# Altium schematic sheet Schamatic1_.SchDoc(Schamatic1_)
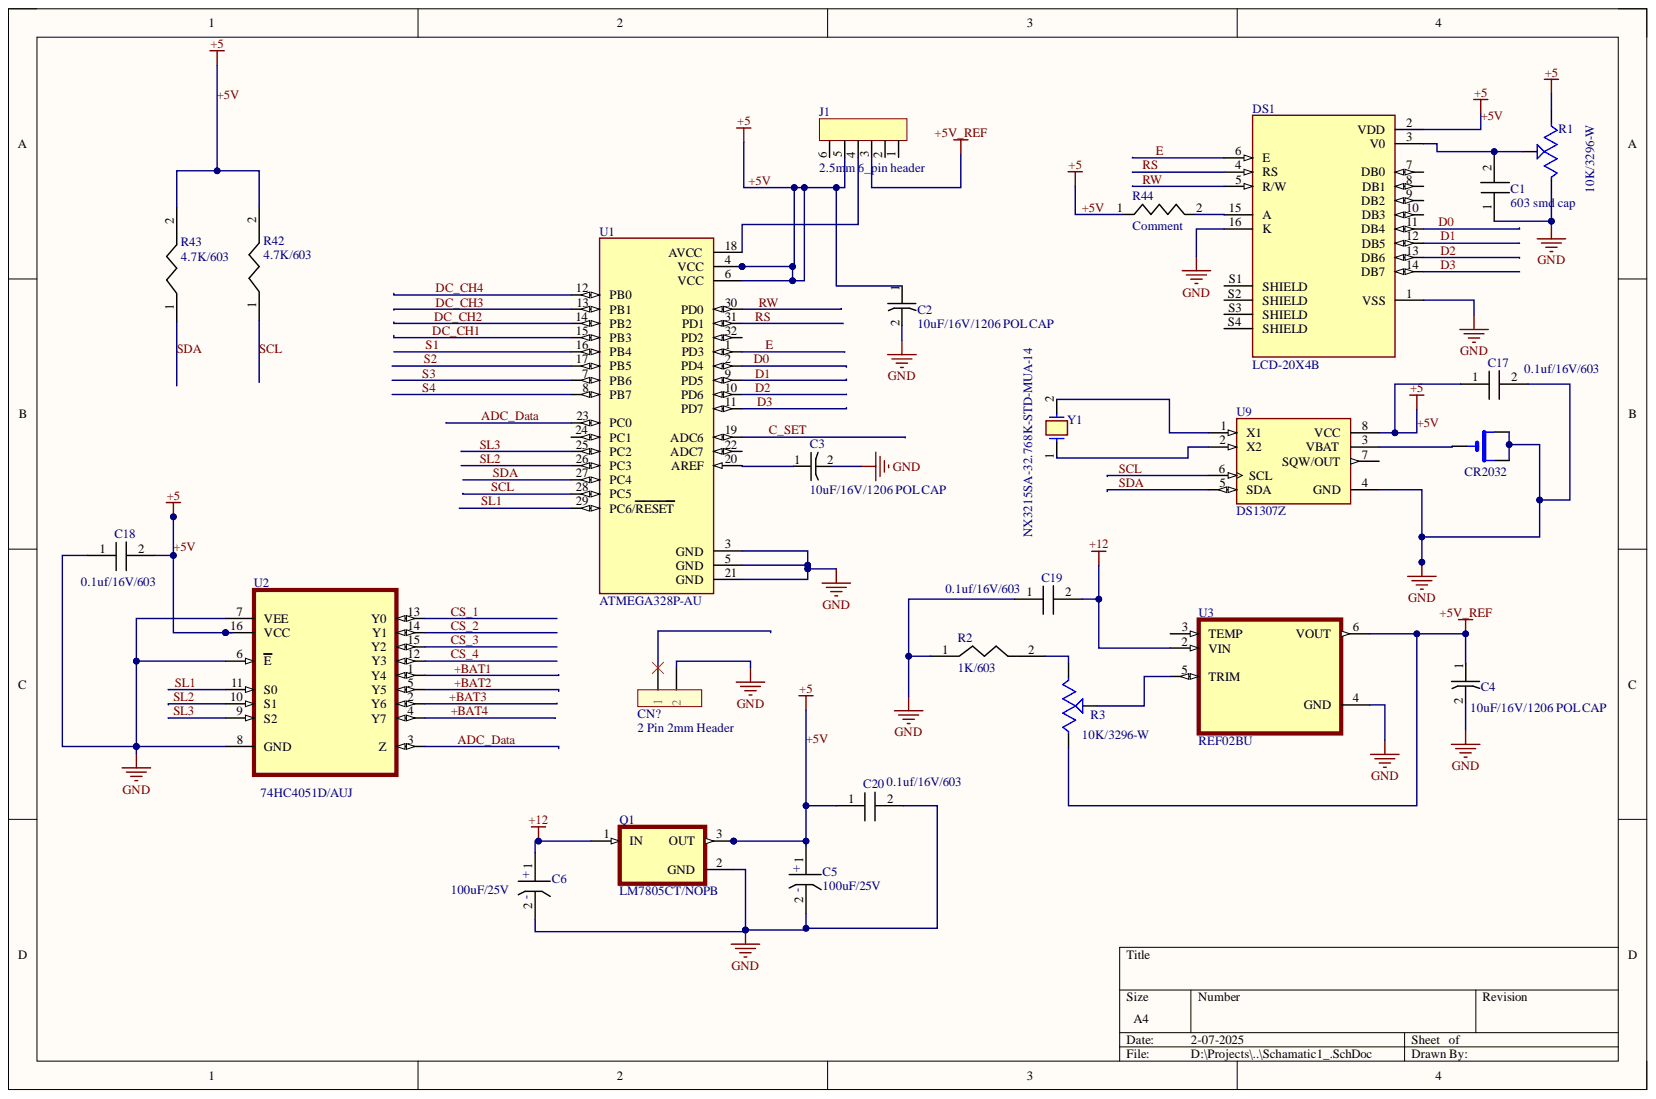
[diagram p0: Schamatic1_.SchDoc]

## Parts
C1  603 smd cap  [603]
C2  10uF/16V/1206 POL CAP  [1206 POL CAP]
C3  10uF/16V/1206 POL CAP  [1206 POL CAP]
C4  10uF/16V/1206 POL CAP  [1206 POL CAP]
C5  100uF/25V  [8mm Dia Eletrolytic Cap]
C6  100uF/25V  [8mm Dia Eletrolytic Cap]
C17  0.1uf/16V/603  [603]
C18  0.1uf/16V/603  [603]
C19  0.1uf/16V/603  [603]
C20  0.1uf/16V/603  [603]
CN?  2 Pin 2mm Header  [2mm 2 pin header]
DS1  LCD-20X4B  [LCD_LCD-20X4B]
J1  2.5mm 6_pin header
Q1  LM7805CT/NOPB  [TO254P1054X470X2600-3]
R1  10K/3296-W  [TRIM_3296-W]
R2  1K/603  [603]
R3  10K/3296-W  [TRIM_3296-W]
R42  4.7K/603  [603]
R43  4.7K/603  [603]
R44  Comment  [1206]
U1  ATMEGA328P-AU  [QFP80P900X900X120-32N]
U2  74HC4051D/AUJ  [SOIC127P600X175-16N]
U3  REF02BU  [SOIC127P599X175-8N]
U9  DS1307Z  [SOIC127P600X175-8N]
Y1  NX3215SA-32.768K-STD-MUA-14  [XTAL_NX3215SA-32.768K-STD-MUA-14]
  CR2032  [CR2032-SMD]

## Nets
+5V_REF: C4.1 J1.3 R3.3 U3.6(VOUT)
+12: C6.1 C19.2 Q1.1 U3.2(VIN)
GND: C1.1 C2.2 C3.2 C4.2 C5.2 C6.2 C17.2 C18.1 C19.1 C20.2 CN?.2 DS1.1(VSS) DS1.16(K) Q1.2 R1.3 R2.1 U1.3(GND) U1.5(GND) U1.21(GND) U2.6(E) U2.7(VEE) U2.8(GND) U3.4(GND) U9.4(GND) .+$1 .+$2
Net_NEG: U9.3(VBAT) .NEG
+5V: C2.1 C5.1 C17.1 C18.2 C20.1 DS1.2(VDD) J1.5 Q1.3 R1.1 R42.2 R43.2 R44.1 U1.4(VCC) U1.6(VCC) U2.16(VCC) U9.8(VCC)
+BAT1: U2.1(Y4)
+BAT2: U2.5(Y5)
+BAT3: U2.2(Y6)
+BAT4: U2.4(Y7)
ADC_Data: U1.23(PC0) U2.3(Z)
C_SET: U1.19(ADC6)
CS_1: U2.13(Y0)
CS_2: U2.14(Y1)
CS_3: U2.15(Y2)
CS_4: U2.12(Y3)
D0: DS1.11(DB4) U1.2(PD4)
D1: DS1.12(DB5) U1.9(PD5)
D2: DS1.13(DB6) U1.10(PD6)
D3: DS1.14(DB7) U1.11(PD7)
DC_CH1: U1.15(PB3)
DC_CH2: U1.14(PB2)
DC_CH3: U1.13(PB1)
DC_CH4: U1.12(PB0)
E: DS1.6(E) U1.1(PD3)
RS: DS1.4(RS) U1.31(PD1)
RW: DS1.5(R/W) U1.30(PD0)
S1: U1.16(PB4)
S2: U1.17(PB5)
S3: U1.7(PB6)
S4: U1.8(PB7)
SCL: R42.1 U1.28(PC5) U9.6(SCL)
SDA: R43.1 U1.27(PC4) U9.5(SDA)
SL1: U1.29(PC6/RESET) U2.11(S0)
SL2: U1.26(PC3) U2.10(S1)
SL3: U1.25(PC2) U2.9(S2)
NetC1_2: C1.2 DS1.3(V0) R1.2
NetC3_1: C3.1 U1.20(AREF)
NetDS1_15: DS1.15(A) R44.2
NetJ1_4: J1.4 U1.18(AVCC)
NetR2_2: R2.2 R3.1
NetR3_2: R3.2 U3.5(TRIM)
NetU9_1: U9.1(X1) Y1.2
NetU9_2: U9.2(X2) Y1.1

Not connected: CN? 1; DS1 7(DB0) 8(DB1) 9(DB2) 10(DB3) S1(SHIELD) S2(SHIELD) S3(SHIELD) S4(SHIELD); J1 1 2 6; U1 22(ADC7) 24(PC1) 32(PD2); U3 3(TEMP); U9 7(SQW/OUT)

## Library data of the parts
C2-C4 = 1206 POL CAP: Component Kind: Standard; Library Name: Custom1.IntLib
C5 C6 = 8 Dia Cap: Component Kind: Standard; Library Name: Custom1.IntLib
C17-C20 = 603 SMD CAP: Component Kind: Standard; Library Name: Custom1.IntLib
CN? = 2_PIN 2mm Header: Component Kind: Standard; Library Name: Custom1.IntLib
DS1 = LCD-20X4B: Display Development Tools 20X4 CHARACTER BLUE LCD W/BACKLIGHT; MF: Gravitech; Availability: In Stock; Check_prices: https://www.snapeda.com/parts/LCD-20X4B/Gravitech/view-part/?ref=eda; Component Kind: Standard; Library Name: LCD-20X4B.IntLib; MP: LCD-20X4B; Package: None; Price: None; Purchase-URL: https://pricing.snapeda.com/search/part/LCD-20X4B/?ref=eda; SnapEDA_Link: https://www.snapeda.com/parts/LCD-20X4B/Gravitech/view-part/?ref=snap
J1 = 6_pin 2.5mm Header: Component Kind: Standard; Library Name: Custom1.IntLib
Q1 = LM7805CT/NOPB: Linear Voltage Regulator IC Positive Fixed 1 Output 1.5A TO-220-3; MF: ON Semiconductor; Availability: In Stock; Check_prices: https://www.snapeda.com/parts/LM7805CT/Onsemi/view-part/?ref=eda; Component Kind: Standard; Library Name: LM7805CT_NOPB.IntLib; MP: LM7805CT; Package: TO-220-3 ON Semiconductor; Price: None; Purchase-URL: https://pricing.snapeda.com/search/part/LM7805CT/?ref=eda; SnapEDA_Link: https://www.snapeda.com/parts/LM7805CT/Onsemi/view-part/?ref=snap
R1 R3 = 3296-W: 5 kOhms 0.5W, 1/2W PC Pins Through Hole Trimmer Potentiometer Cermet 25 Turn Top Adjustment; MF: Bourns; Availability: Unavailable; Component Kind: Standard; Library Name: 3296-W.IntLib; MP: 3296-W; Package: SOT-23-5 Bourns; Price: None; SnapEDA_Link: https://www.snapeda.com/parts/3296-W/Bourns/view-part/703041/?ref=snap
R2 R42 R43 = 603 Resistor: Component Kind: Standard; Library Name: Custom1.IntLib
R44 = 1206 Resistor: Component Kind: Standard; Library Name: Custom1.IntLib
U1 = ATMEGA328P-AU: AVR AVRÂ® ATmega Microcontroller IC 8-Bit 20MHz 32KB (16K x 16) FLASH 32-TQFP (7x7); MF: Microchip Technology; Check_prices: https://www.snapeda.com/parts/ATMEGA328P-AU/Microchip/view-part/?ref=eda; Component Kind: Standard; Library Name: ATMEGA328P-AU.IntLib; MP: ATMEGA328P-AU; Package: TQFP-32 Microchip; SnapEDA_Link: https://www.snapeda.com/parts/ATMEGA328P-AU/Microchip/view-part/?ref=snap
U2 = 74HC4051D/AUJ: 1 Circuit IC Switch 8:1 130Ohm 16-SO; MF: NXP USA; Availability: Unavailable; Component Kind: Standard; Library Name: 74HC4051D_AUJ.IntLib; MP: 74HC4051D/AUJ; Package: SOIC-16 NXP Semiconductors; Price: None; SnapEDA_Link: https://www.snapeda.com/parts/74HC4051D/AUJ/NXP%20USA%20Inc./view-part/886776/?ref=snap
U3 = REF02BU: Wide supply range, 5-V output precision voltage reference 8-SOIC -40 to 85; MF: Texas Instruments; Availability: Good; Check_prices: https://www.snapeda.com/parts/REF02BU/Texas%20Instruments/view-part/386439/?ref=eda; Component Kind: Standard; Library Name: REF02BU.IntLib; MP: REF02BU; Package: SOIC-8 Texas Instruments; Price: None; Purchase-URL: https://pricing.snapeda.com/search/part/REF02BU/?ref=eda; SnapEDA_Link: https://www.snapeda.com/parts/REF02BU/Texas%20Instruments/view-part/386439/?ref=snap
U9 = DS1307Z: Real Time Clock _RTC_ IC Clock/Calendar 56B IÂ²C, 2-Wire Serial 8-SOIC _0.154, 3.90mm Width_; MF: Maxim Integrated; Availability: Unavailable; Component Kind: Standard; Library Name: DS1307Z.IntLib; MP: DS1307Z; Package: SO-8 Maxim Integrated; Price: None; SnapEDA_Link: https://www.snapeda.com/parts/DS1307Z/Maxim%20Integrated/view-part/524266/?ref=snap
Y1 = NX3215SA-32.768K-STD-MUA-14: 32.768kHz Â±20ppm Crystal 6pF 70 kOhms 2-SMD, No Lead  MANUFACTURER: NIHON DEMPA KOGYO; Availability: Unavailable; Component Kind: Standard; Library Name: NX3215SA-32.768K-STD-MUA-14.IntLib; MP: NX3215SA-32.768K-STD-MUA-14; Package: None; Price: None
 = CR2032: Coin, 20.0mm Lithium Manganese Dioxide 3V Battery Non-Rechargeable _Primary_; MF: FDK America,; Availability: Unavailable; Component Kind: Standard; Library Name: CR2032.IntLib; MP: CR2032; Package: FDK; Price: None; SnapEDA_Link: https://www.snapeda.com/parts/CR2032/FDK%20America%2C%20Inc.%2C%20a%20member%20of%20Fujitsu%20Group/view-part/1775317/?ref=snap
C1 = 603 SMD CAP: Component Kind: Standard; Library Name: Custom1.IntLib
